# Altium schematic sheet MPU6050_Gyro_Accel_Temp.SchDoc
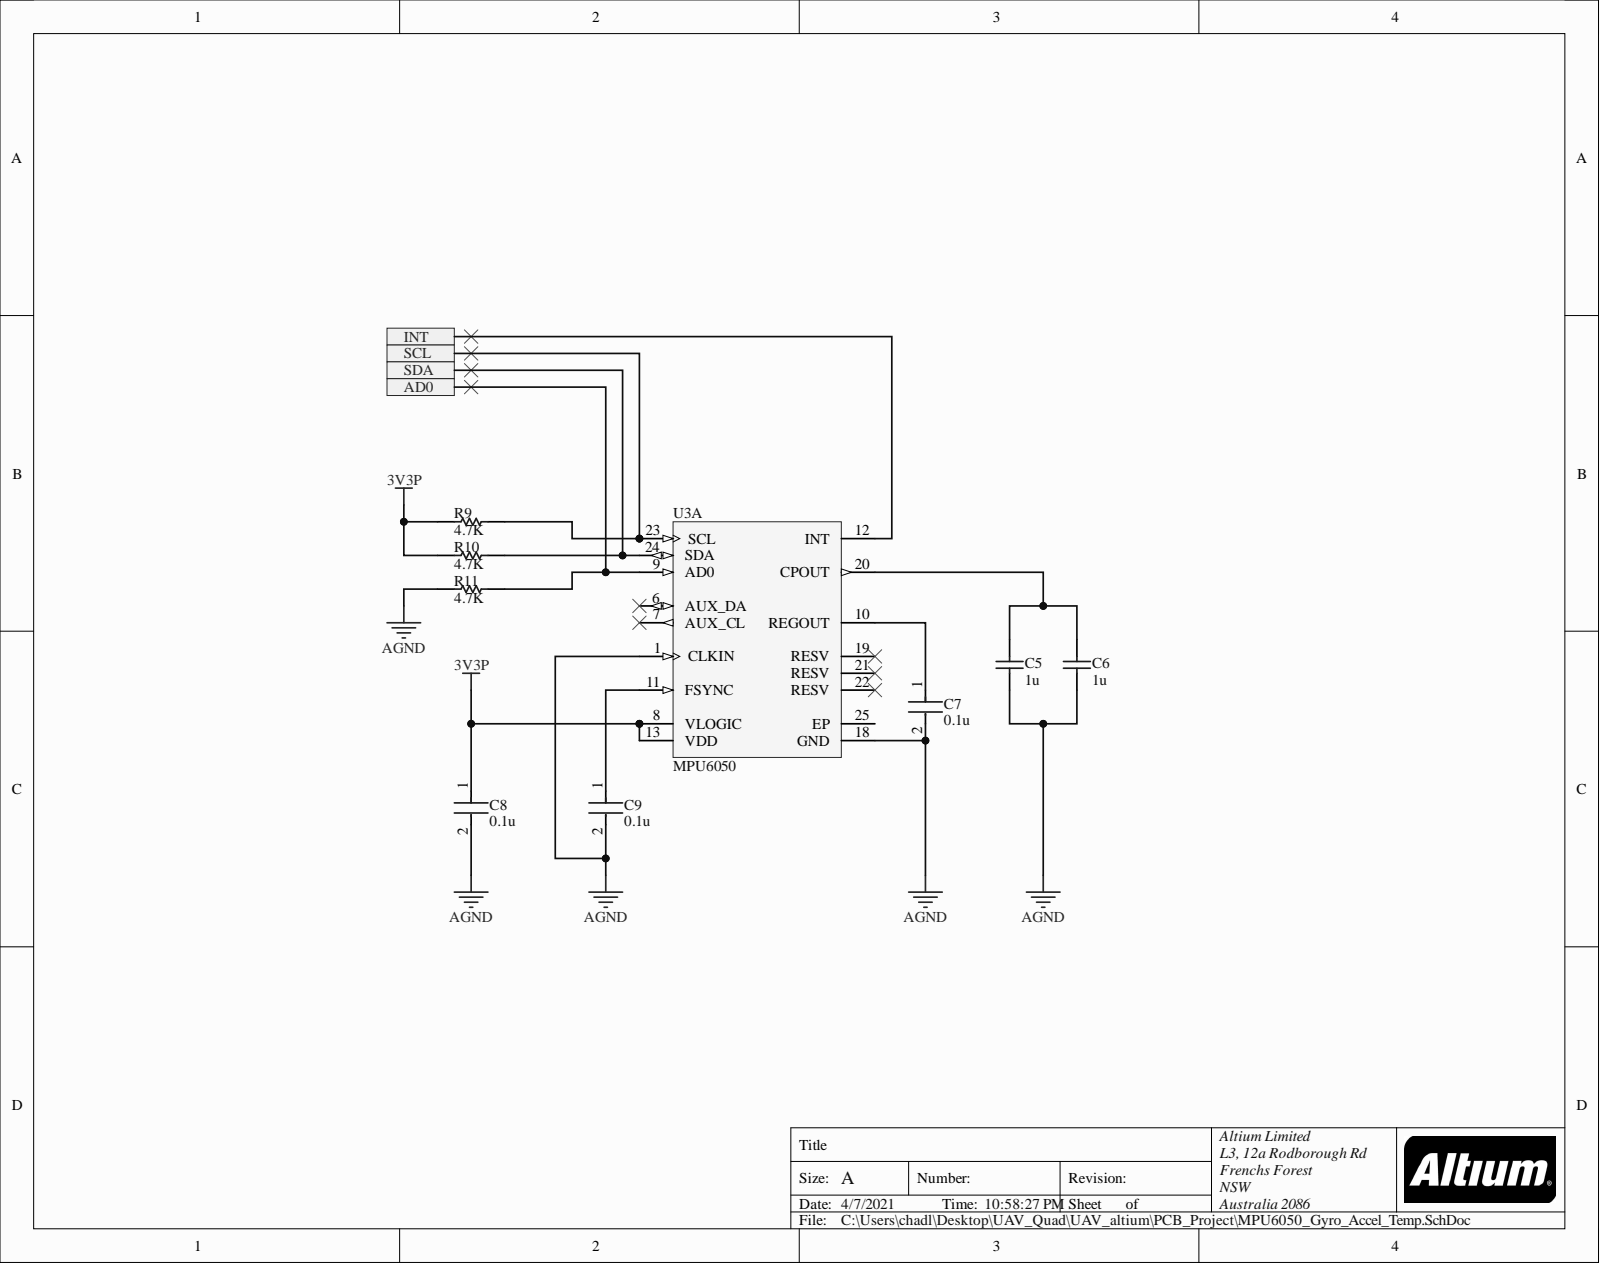
[diagram p0: MPU6050_Gyro_Accel_Temp.SchDoc]

## Parts
C5  1u  [CAPC3216X110X50LL20T25]
C6  1u  [CAPC3216X110X50LL20T25]
C7  0.1u  [FP-C0402-BB-MFG]
C8  0.1u  [FP-C0402-BB-MFG]
C9  0.1u  [FP-C0402-BB-MFG]
R9  4.7K  [RESC3216X70X50LL05T20]
R10  4.7K  [RESC3216X70X50LL05T20]
R11  4.7K  [RESC3216X70X50LL05T20]
U3  MPU6050  [INSE-QFN-24_V]  (part A)

## Ports
AD0, INT, SCL, SDA

## Nets
3V3P: C8.1 R9.1 R10.1 U3.8(VLOGIC) U3.13(VDD)
AGND: C5.1 C6.1 C7.2 C8.2 C9.2 R11.1 U3.1(CLKIN) U3.18(GND)
AD0: R11.2 U3.9(AD0) ; port AD0
INT: U3.12(INT) ; port INT
SCL: R9.2 U3.23(SCL) ; port SCL
SDA: R10.2 U3.24(SDA) ; port SDA
NetC5_2: C5.2 C6.2 U3.20(CPOUT)
NetC7_1: C7.1 U3.10(REGOUT)
NetC9_1: C9.1 U3.11(FSYNC)

Not connected: U3 6(AUX_DA) 7(AUX_CL) 19(RESV) 21(RESV) 22(RESV) 25(EP)

## Library data of the parts
C5 C6 = CMP-2000-06011-1: Chip Capacitor, 1 uF, +/- 10%, 50 V, -55 to 125 degC, 1206 (3216 Metric), RoHS, Tape and Reel; Brand: Kemet; Capacitance: 1uF; Capacitance - nF: 1000nF; Case Height: 1mm; Case Length: 3.2mm; Case Width: 1.6mm; Class: Class1; Dielectric: X7R; Temperature Coefficient / Code: +/-15%; Type: SMDMLCCX7R6.3-250VDCCommericalGrade; Voltage Rating: 50V; Case Code - in: 1206; Case Code - mm: 3216; Component Kind: Standard; Datasheet URL: http://www.kemet.com/docfinder?Partnumber=C1206C105K5RACTU; Datasheet Version: 02/2016; Dimensions: 1.6mmWx3.2mmLx1mmH; Factory Pack Quantity: 2500; Library Name: Altium Content Vault; Manufacturer: Kemet; Manufacturer URL: http://www.kemet.com/; Maximum Operating Temperature: +125C; Minimum Operating Temperature: -55C; Mounting Technology: SurfaceMount; Operating Temperature Range: -55Cto+125C; Package / Case: 1206(3216metric); Package Description: 2-Pin Surface Mount Device, Body 3.2 x 1.6 mm; Package Reference: 1206; Packaging: Reel; Product: GeneralTypeMLCCs; Product Category: MultilayerCeramicCapacitorsMLCC-SMD/SMT; RoHS: Details; Series: C1206C; Termination Style: SMD/SMT; Tolerance: 10%; Voltage Rating DC: 50V
C7-C9 = CMP-2008-02046-2: CAP CER 0.1UF 10V X5R 0402; Manufacturer: Kemet; Manufacturer Part Number: C0402C104K8PACTU; Capacitance: 0.10 μF; Material: Ceramic; Temperature Coefficient: 15%; Tolerance: 0.1; Value: 0.10 μF; Automotive: No; Category: Cap; Component Kind: Standard; ComponentLink2Description: Datasheet; ComponentLink2URL: https://content.kemet.com/datasheets/KEM_C1002_X7R_SMD.pdf; Device Class L1: Passive Components; Device Class L2: Capacitors; Device Class L3: Ceramic Capacitors; Digikey Description: CAP CER 0.1UF 10V X5R 0402; Height: 0.55mm; Ipc Land Pattern Name: CAPC10050X50; Lead Free: Yes; Library Name: Altium Content Vault; Mouser Description: Capacitor 100 nF 10 VDC 0402; Octopart: https://octopart.com/c0402c104k8pactu-kemet-140039; Package: C0402-BB; RoHS: TRUE; Temperature: -55°C to +125°C; Temperature Characteristic: X5R; Temperature Range High: +125°C; Temperature Range Low: -55°C; Voltage Rating: 10 V
R9-R11 = CMP-2003-00848-1: Manufacturer: Panasonic; Part Number: ERJ8ENF4701V; Component Kind: Standard; Library Name: Altium Content Vault
U3 = CMP-1753-00002-1: 3-Axis Gyroscope, 3-Axis Accelerometer  , 2.375 to 3.46 V, -40 to 105 degC, 24-Pin QFN, RoHS, Tape and Reel; Power Rating: 100 mW; Resistance: 5.6 kohm; Tolerance: 1%; Voltage Rating: 75 V; Case Code (Imperial): 0603; Case Code (Metric): 1608; Case/Package: 0603; Component Kind: Standard; ComponentLink1Description: Manufacturer URL; ComponentLink1URL: http://www.invensense.com/; ComponentLink2Description: Datasheet; ComponentLink2URL: https://datasheet.ciiva.com/1513/1788002-1513632.pdf; DatasheetVersion: Rev. 3.4, 08/2013; Height: 0.45 mm; Length: 1.6 mm; Library Name: Altium Content Vault; Max Operating Temperature: 155degC; Min Operating Temperature: -55degC; Mount: Surface Mount; Package Quantity: 5000; PackageDescription: 24-Pin Quad Flat No-lead Package, Body 4 x 4 mm, Pitch 0.5 mm; PackageReference: QFN-24; Packaging: Tape and Reel; Pins: 2; Radiation Hardening: No; REACH SVHC: Yes; RoHSCompliant: True; Width: 0.8 mm
